# Altium schematic sheet Power.SchDoc
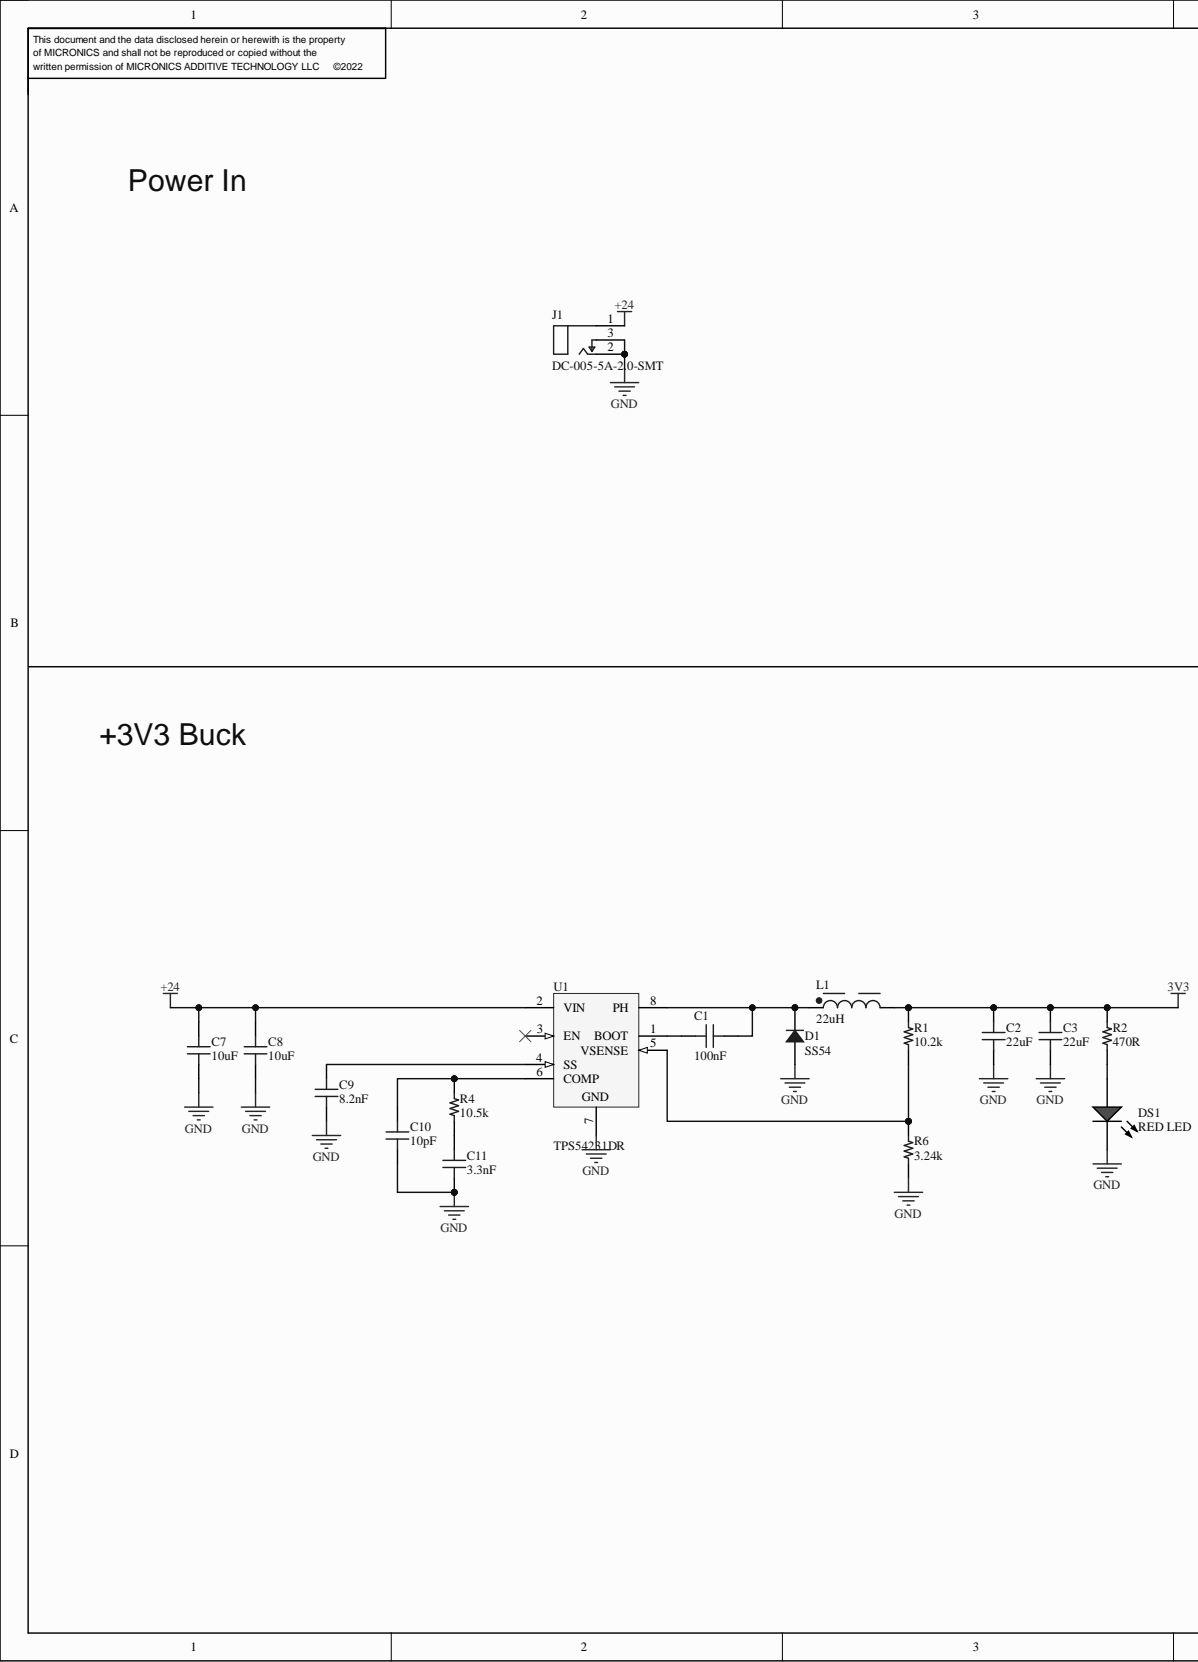
[diagram p0: Power.SchDoc, left]
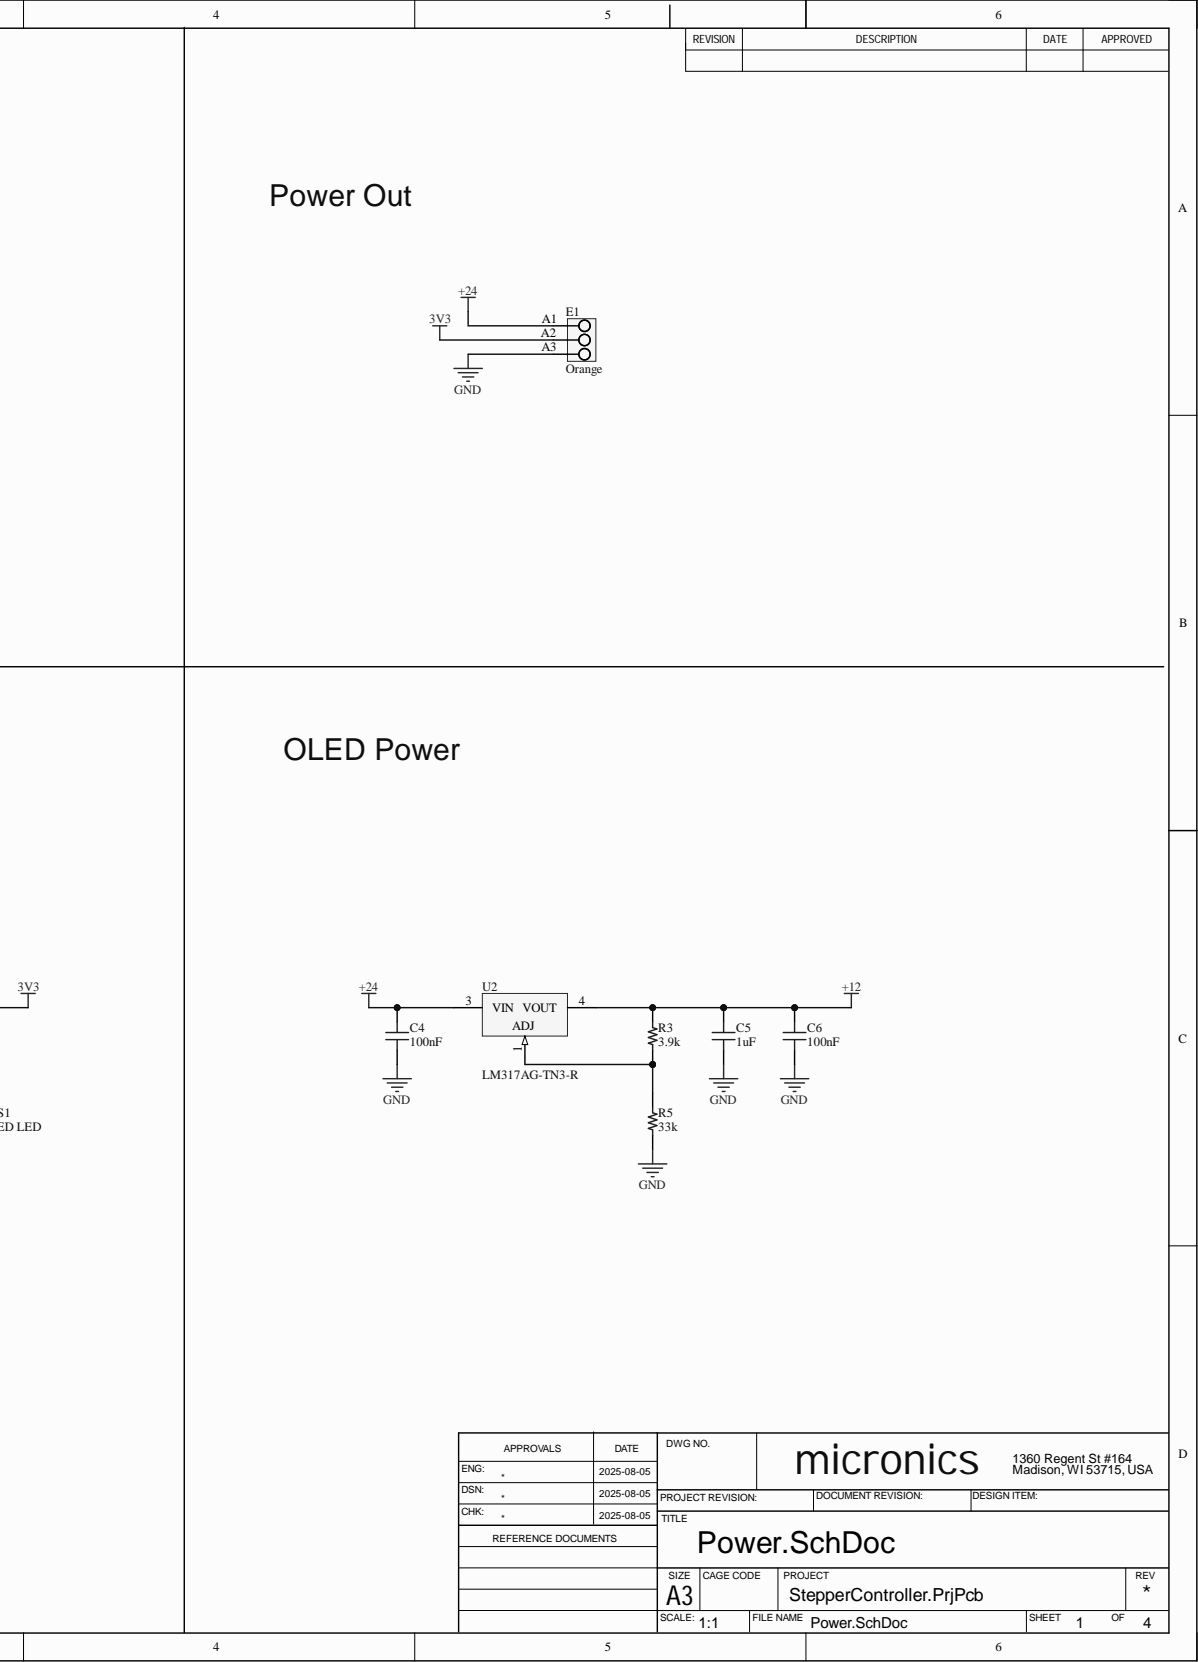
[diagram p1: Power.SchDoc, right]

## Parts
C1  100nF  [CAP 0603_1608]
C2  22uF  [CAP 1206_3216 - 0.8MM]
C3  22uF  [CAP 1206_3216 - 0.8MM]
C4  100nF  [CAP 0603_1608]
C5  1uF  [CAP 0603_1608]
C6  100nF  [CAP 0603_1608]
C7  10uF  [CAP 1206_3216 - 0.8MM]
C8  10uF  [CAP 1206_3216 - 0.8MM]
C9  8.2nF  [CAP 0603_1608]
C10  10pF  [CAP 0603_1608]
C11  3.3nF  [CAP 0603_1608]
D1  SS54  [DO214AC SMA]
DS1  RED LED  [VISH-TLMS1000_V]
E1  Orange  [PM_5_08_90_03]
J1  DC-005-5A-2.0-SMT  [FP-PJ-002BH-SMT-TR-MFG]
L1  22uH  [WE-PD_1050P]
R1  10.2k  [RES 0603_1608]
R2  470R  [RES 0603_1608]
R3  3.9k  [RES 0603_1608]
R4  10.5k  [RES 0603_1608]
R5  33k  [RES 0603_1608]
R6  3.24k  [RES 0603_1608]
U1  TPS54231DR  [D0008A_L]
U2  LM317AG-TN3-R  [TO-252_L]

## Nets
3V3: C2.2 C3.2 E1.A2 L1.2 R1.2 R2.2
+12: C5.1 C6.1 R3.2 U2.4
+24: C4.1 C7.2 C8.2 E1.A1 J1.1 U1.2(VIN) U2.3
GND: C2.1 C3.1 C4.2 C5.2 C6.2 C7.1 C8.1 C9.1 C10.1 C11.1 D1.1 DS1.2 E1.A3 J1.2 J1.3 R5.1 R6.1 U1.7
NetC1_1: C1.1 D1.2 L1.1 U1.8(PH)
NetC1_2: C1.2 U1.1(BOOT)
NetC9_2: C9.2 U1.4(SS)
NetC10_2: C10.2 R4.2 U1.6(COMP)
NetC11_2: C11.2 R4.1
NetDS1_1: DS1.1 R2.1
NetR1_1: R1.1 R6.2 U1.5(VSENSE)
NetR3_1: R3.1 R5.2 U2.1

Not connected: U1 3(EN)

## Library data of the parts
C1 = 250X14W223MV4T: C14663; manufacturer: Johanson Dielectrics Inc.; Database Table Name: Capacitors - Ceramic - 0603; Temperature Coefficient: X7R; Tolerance: ±20%; Voltage - Rated: 25V; Applications: Bypass, Decoupling; Capacitance: 100nF; Component Kind: Standard; componentlink1description: Datasheet; componentlink1url: https://www.johansondielectrics.com/downloads/x2y-filter-and-decoupling-capacitors.pdf; componentlink2description: DigiKey Link; componentlink2url: https://www.digikey.com/en/products/detail/johanson-dielectrics-inc/250X14W223MV4T/1628740; Device Package: 0603 (1608 Metric); Features: Low ESL (X2Y); lastupdated: 2022-05-16 11:25:57 AM; Library Name: Celestial Altium Library - altium_library.DbLib; Lifecycle Status: Active; Minimum Order: 1; Mounting Type: Surface Mount, MLCC; Operating Temperature: -55°C ~ 125°C; Package / Case: 0603 (1608 Metric); packaging: TapeAndReel; Part Number: 250X14W223MV4T; Part Status: Active; partid: 14325; price: 0.07; series: X2Y®; Size / Dimension: 0.064" L x 0.035" W (1.63mm x 0.89mm); Supplier 1: DigiKey; Supplier Part Number 1: 709-1087-1-ND; Thickness (Max): 0.026" (0.66mm); Value: 100nF
C2 C3 = MM061A101JCT2A: C12891; manufacturer: AVX Corporation; Database Table Name: Capacitors - Ceramic - 1206; Temperature Coefficient: C0G, NP0; Tolerance: ±5%; Voltage - Rated: 100V; Applications: Medical, Non-Critical; Capacitance: 22uF; Component Kind: Standard; componentlink1description: Datasheet; componentlink1url: http://datasheets.avx.com/AVX-MM.pdf; componentlink2description: DigiKey Link; componentlink2url: http://digikey.com/product-detail/en/avx-corporation/MM061A101JCT2A/478-10622-1-ND/7344883; Device Package: 1206 (3216 Metric); lastupdated: 2020-09-02 1:04:46 AM; Library Name: Celestial Altium Library - altium_library.DbLib; Lifecycle Status: Active; Minimum Order: 1; Mounting Type: Surface Mount, MLCC; Operating Temperature: -55°C ~ 125°C; Package / Case: 1206 (3216 Metric); packaging: TapeAndReel; Part Number: MM061A101JCT2A; Part Status: Active; partid: 18685; price: 0.52; series: MM; Size / Dimension: 0.126" L x 0.063" W (3.20mm x 1.60mm); Supplier 1: DigiKey; Supplier Part Number 1: 478-10622-1-ND; Thickness (Max): 0.029" (0.74mm); Value: 22uF
C4-C6 = 250X14W223MV4T: CAP CER 0.022UF 25V X7R 0603; manufacturer: Johanson Dielectrics Inc.; Database Table Name: Capacitors - Ceramic - 0603; Tolerance: ±20%; Applications: Bypass, Decoupling; Component Kind: Standard; componentlink1description: Datasheet; componentlink1url: https://www.johansondielectrics.com/downloads/x2y-filter-and-decoupling-capacitors.pdf; componentlink2description: DigiKey Link; componentlink2url: https://www.digikey.com/en/products/detail/johanson-dielectrics-inc/250X14W223MV4T/1628740; Device Package: 0603 (1608 Metric); Features: Low ESL (X2Y); lastupdated: 2022-05-20 2:39:33 PM; Library Name: Celestial Altium Library - altium_library.DbLib; Lifecycle Status: Active; Minimum Order: 1; Mounting Type: Surface Mount, MLCC; Operating Temperature: -55°C ~ 125°C; Package / Case: 0603 (1608 Metric); packaging: TapeAndReel; Part Number: 250X14W223MV4T; Part Status: Active; partid: 14325; price: 0.07; series: X2Y®; Size / Dimension: 0.064" L x 0.035" W (1.63mm x 0.89mm); Supplier 1: DigiKey; Supplier Part Number 1: 709-1087-1-ND; Temperature Coefficient: X7R; Thickness (Max): 0.026" (0.66mm); Voltage - Rated: 25V
  C4 C6: Capacitance: 100nF; Value: 100nF
  C5: Capacitance: 1uF; Value: 1uF
C7 C8 = MM061A101JCT2A: C13585; manufacturer: AVX Corporation; Database Table Name: Capacitors - Ceramic - 1206; Temperature Coefficient: C0G, NP0; Tolerance: ±5%; Voltage - Rated: 100V; Applications: Medical, Non-Critical; Capacitance: 10uF; Component Kind: Standard; componentlink1description: Datasheet; componentlink1url: http://datasheets.avx.com/AVX-MM.pdf; componentlink2description: DigiKey Link; componentlink2url: http://digikey.com/product-detail/en/avx-corporation/MM061A101JCT2A/478-10622-1-ND/7344883; Device Package: 1206 (3216 Metric); lastupdated: 2020-09-02 1:04:46 AM; Library Name: Celestial Altium Library - altium_library.DbLib; Lifecycle Status: Active; Minimum Order: 1; Mounting Type: Surface Mount, MLCC; Operating Temperature: -55°C ~ 125°C; Package / Case: 1206 (3216 Metric); packaging: TapeAndReel; Part Number: MM061A101JCT2A; Part Status: Active; partid: 18685; price: 0.52; series: MM; Size / Dimension: 0.126" L x 0.063" W (3.20mm x 1.60mm); Supplier 1: DigiKey; Supplier Part Number 1: 478-10622-1-ND; Thickness (Max): 0.029" (0.74mm); Value: 10uF
C9-C11 = 250X14W223MV4T: manufacturer: Johanson Dielectrics Inc.; Database Table Name: Capacitors - Ceramic - 0603; Temperature Coefficient: X7R; Tolerance: ±20%; Voltage - Rated: 25V; Applications: Bypass, Decoupling; Component Kind: Standard; componentlink1description: Datasheet; componentlink1url: https://www.johansondielectrics.com/downloads/x2y-filter-and-decoupling-capacitors.pdf; componentlink2description: DigiKey Link; componentlink2url: https://www.digikey.com/en/products/detail/johanson-dielectrics-inc/250X14W223MV4T/1628740; Device Package: 0603 (1608 Metric); Features: Low ESL (X2Y); lastupdated: 2022-05-16 11:25:57 AM; Library Name: Celestial Altium Library - altium_library.DbLib; Lifecycle Status: Active; Minimum Order: 1; Mounting Type: Surface Mount, MLCC; Operating Temperature: -55°C ~ 125°C; Package / Case: 0603 (1608 Metric); packaging: TapeAndReel; Part Number: 250X14W223MV4T; Part Status: Active; partid: 14325; price: 0.07; series: X2Y®; Size / Dimension: 0.064" L x 0.035" W (1.63mm x 0.89mm); Supplier 1: DigiKey; Supplier Part Number 1: 709-1087-1-ND; Thickness (Max): 0.026" (0.66mm)
  C9: Capacitance: 8.2nF; Value: 8.2nF
  C10: Capacitance: 10pF; Value: 10pF
  C11: Capacitance: 3.3nF; Value: 3.3nF
D1 = RS1A: Manufacturer: ON Semiconductor; Capacitance @ Vr, F: 10pF @ 4V, 1MHz; Current - Average Rectified (Io): 1A; Current - Reverse Leakage @ Vr: 5µA @ 50V; Database Table Name: Diodes - Rectifiers - Single; Diode Type: Standard; Reverse Recovery Time (trr): 150ns; Speed: Fast Recovery =< 500ns, > 200mA (Io); Voltage - DC Reverse (Vr) (Max): 50V; Voltage - Forward (Vf) (Max) @ If: 1.3V @ 1A; Component Kind: Standard; ComponentLink1Description: Datasheet; ComponentLink1URL: https://www.onsemi.com/pub/Collateral/RS1M-D.PDF; ComponentLink2Description: DigiKey Link; ComponentLink2URL: http://digikey.com/product-detail/en/on-semiconductor/RS1A/RS1ACT-ND/3504478; Description: SS54; LastUpdated: 2022-05-20 3:23:52 PM; Library Name: Celestial Altium Library - altium_library.DbLib; Lifecycle Status: Active; Minimum Order: 1; Mounting Type: Surface Mount; Operating Temperature - Junction: -55°C ~ 150°C; Package / Case: DO-214AC, SMA; Packaging: TapeAndReel; Part Number: SS54; Part Status: Active; PartId: 585; Price: 0.08; Series: -; Supplier 1: DigiKey; Supplier Device Package: SMA (DO-214AC); Supplier Part Number 1: RS1ACT-ND
DS1 = CMP-2000-07254-1: 0603 LED; Manufacturer: Vishay; Automotive Qualification Standard: AEC-Q100; Bulb Size: 1.6mmx0.8mm; Forward Current If: 2mA; Forward Voltage: 1.8V; LED Mounting: SMD; Lens Shape: Rectangular; Luminous Intensity: 4mcd; SVHC: ToBeAdvised; Viewing Angle: 80°; Wavelength Typ: 636nm; Component Kind: Standard; Datasheet URL: https://datasheet.ciiva.com/5798/1698881-5798337.pdf; Datasheet Version: Rev. 1.7, 02/2011; LED Colour: Red; Library Name: Altium Content Vault; Manufacturer URL: http://www.vishay.com/; Mounting Technology: SurfaceMount; MSL: MSL2-1year; Package Description: 2-Pin Surface Mount Device, Body 1.6 x 0.8 mm; Package Reference: TLMS1000; Packaging: CutTape; Product Range: -
E1 = CMP-1559-01629-1: C430604; Manufacturer: Weidmueller; PartNumber: 1760500000; Contact_material: Cu-Leg; Contact_surface: tinned; Insulating_material: Wemid (PA); Mounting_onto_the_PCB: Solder connection; No_of_poles: 3; Pitch_inch: 0,2 inch; Pitch_mm: 5,08 mm; Rated_current_use_group_B_UL_1059: 15 A; Rated_voltage_for_surge_voltage_class_pollution_degree_II_2: 600 V; Rated_voltage_use_group_B_UL_1059: 300 V; Short_text_for_material: PM 5.08/03/90 3.5SN OR BX; Side_termination_characteristic: closed side; Solder_pin_length_mm: 3,5 mm; Solid_max_H05_07_V_U: 2,5 mm²; Solid_min_H05_07_V_U: 0,13 mm²; UL_94_flammability_rating: V-0; Wire_cross_section_AWG_max_UL_1059: AWG 14; Wire_cross_section_AWG_min_UL_1059: AWG 26; Colour: Orange; Component Kind: Standard; ComponentLink1Description: Manufacturer URL; ComponentLink1URL: http://www.weidmueller.com/; ComponentLink2Description: URL Link1; ComponentLink2URL: http://catalog.weidmueller.com/catalog/Start.do?localeId=en&ObjectID=1760500000; Library Name: Altium Content Vault; PackageReference: PM_5_08_90_03; Packaging: Box; Qty: 500 PCS; Revision: V1
J1 = CMP-2000-06897-2: C319134; Manufacturer: CUI Inc; Manufacturer Part Number: PJ-002BH-SMT-TR; Conn Gender: Male; Conn Orientation: Right Angle; Current Rating: 5A; Number Of Contacts: 3; Standoff Height: 0.1mm; Voltage Rating: 24V; Automotive: No; Category: Conn; Component Kind: Standard; ComponentLink2Description: Datasheet; ComponentLink2URL: https://www.cuidevices.com/product/resource/digikeypdf/pj-002bh-smt-tr.pdf; Contact Material: Nickel,Silver; Device Class L1: Connectors; Device Class L2: Power Connectors; Device Class L3: unset; Digikey Description: CONN PWR JACK 2.5X5.5MM SOLDER; Height: 11.43mm; Lead Free: Yes; Library Name: Altium Content Vault; Mouser Description: 2.5 mm Center Pin, 5.0 A, Right Angle, Surface Mount (SMT), Dc Power Jack Connector; Octopart: https://octopart.com/pj-002bh-smt-tr-cui-25947151; Package: 0; RoHS: TRUE; Temperature: -25°C to +85°C; Temperature Range High: +85°C; Temperature Range Low: -25°C
L1 = CMP-1396-00034-2: C2651173; NexarPartId: 22866943; Core Material: Ferrite; Current Rating: 3A; DC Resistance (DCR): 42mΩ; Inductance: 22µH; Inductance Tolerance: 20%; Resistance: 50mΩ; Saturation Current: 3.6A; Self Resonant Frequency: 14.5MHz; Shielding: Shielded; Test Frequency: 100kHz; Ambient Temperature Range High: 110°C; Ambient Temperature Range Low: -40°C; Case/Package: Nonstandard; Component Kind: Standard; Composition: Wirewound; Depth: 10mm; Height: 5mm; Height - Seated (Max): 5.0038mm; Length: 10mm; Library Name: Altium Content Vault; Max DC Current: 3A; Max Operating Temperature: 150°C; Min Operating Temperature: -40°C; Mount: Surface Mount; Number of Pins: 2; Packaging: Tape & Reel (TR); REACH SVHC: Unknown; RMS Current (Irms): 3A; RoHS: Compliant; Series Resistance: 50mΩ; Termination: SMD/SMT; Tolerance: 20%; Width: 10mm
R1-R6 = RNCF0603DTE23K2: RES 23.2K OHM 0.5% 1/10W 0603; Manufacturer: Stackpole Electronics Inc; Database Table Name: Resistors - Surface Mount - 0603; Power (Watts): 0.1W, 1/10W; Temperature Coefficient: ±25ppm/°C; Component Kind: Standard; ComponentLink1Description: Datasheet; ComponentLink1URL: https://www.seielect.com/catalog/sei-rncf.pdf; ComponentLink2Description: DigiKey Link; ComponentLink2URL: https://www.digikey.com/en/products/detail/stackpole-electronics-inc/RNCF0603DTE23K2/6264115; Composition: Thin Film; Device Package: 0603 (1608 Metric); Features: Automotive AEC-Q200; Height - Seated (Max): 0.022" (0.55mm); LastUpdated: 2022-05-20 3:01:33 PM; Library Name: Celestial Altium Library - altium_library.DbLib; Lifecycle Status: Active; Minimum Order: 1; Number of Terminations: 2; Operating Temperature: -55°C ~ 155°C; Package / Case: 0603 (1608 Metric); Packaging: TapeAndReel; Part Number: RNCF0603DTE23K2; Part Status: Active; PartId: 5426; Price: 0.01; Ratings: AEC-Q200; Series: RNCF; Size / Dimension: 0.061" L x 0.031" W (1.55mm x 0.80mm); Supplier 1: DigiKey; Supplier Device Package: 0603; Supplier Part Number 1: RNCF0603DTE23K2CT-ND; Tolerance: ±0.5%
  R1: Resistance: 10.2k; Value: 10.2k
  R2: Resistance: 470R; Value: 470R
  R3: Resistance: 3.9k; Value: 3.9k
  R4: Resistance: 10.5k; Value: 10.5k
  R5: Resistance: 33k; Value: 33k
  R6: Resistance: 3.24k; Value: 3.24k
U1 = CMP-0323-00207-3: Buck Step Down Regulator with 3.5 to 28 V Input and 0.8 to 25 V Output, -40 to 150 degC, 8-Pin SOIC (D), Green (RoHS & no Sb/Br); Manufacturer: Texas Instruments; Duty Cycle(Max)(%): 90; Iout(Max)(A): 2; Iq(Typ)(mA): 0.11; Rating: Catalog; Regulated Outputs(#): 1; SubFamily: Step-Down Regulator; Switch Current Limit(Typ)(A): 2.3; Vin(Max)(V): 28; Vin(Min)(V): 3.5; Vout(Max)(V): 25; Vout(Min)(V): 0.8; Code_JEDEC: MS-012AA; Component Kind: Standard; ComponentLink1Description: Datasheet; ComponentLink1URL: http://www.ti.com/general/docs/lit/getliterature.tsp?genericPartNumber=TPS54231&fileType=pdf; ComponentLink2Description: Package Specification; ComponentLink2URL: http://www.ti.com/litv/pdf/msoi002j; ComponentLink3Description: URL Link1; ComponentLink3URL: Samples URL:http://www.ti.com/product/tps54231#samples; DatasheetVersion: SLUS851C; Eco-Plan: Green (RoHS & no Sb/Br); Library Name: Altium Content Vault; Mounting Technology: Surface Mount; PackageDescription: 8-Pin Plastic Small Outline Integrated Circuit, 4.9 x 3.9 mm, Pitch 1.27 mm Pitch; PackageReference: D0008A; PackageVersion: revM, Jun-2011; PartNumber: TPS54231DR; Topology: Buck
U2 = CMP-0244-00074-1: C75510; Component Kind: Standard; ComponentLink1Description: Manufacturer URL; ComponentLink1URL: http://www.st.com; ComponentLink2Description: Datasheet; ComponentLink2URL: http://www.st.com/internet/com/TECHNICAL_RESOURCES/TECHNICAL_LITERATURE/DATASHEET/CD00000549.pdf; DatasheetVersion: 1/02/2008; Library Name: Altium Content Vault; PackageDescription: 3-Pin Transistor Outline Package 2.28 mm Pitch, 9.7 mm Lead Span (DPAK); PackageReference: TO-252; PackageVersion: 2011
